# Altium schematic sheet [06] - RS232 INTERFACE.SchDoc("[06] - RS232 INTERFACE")
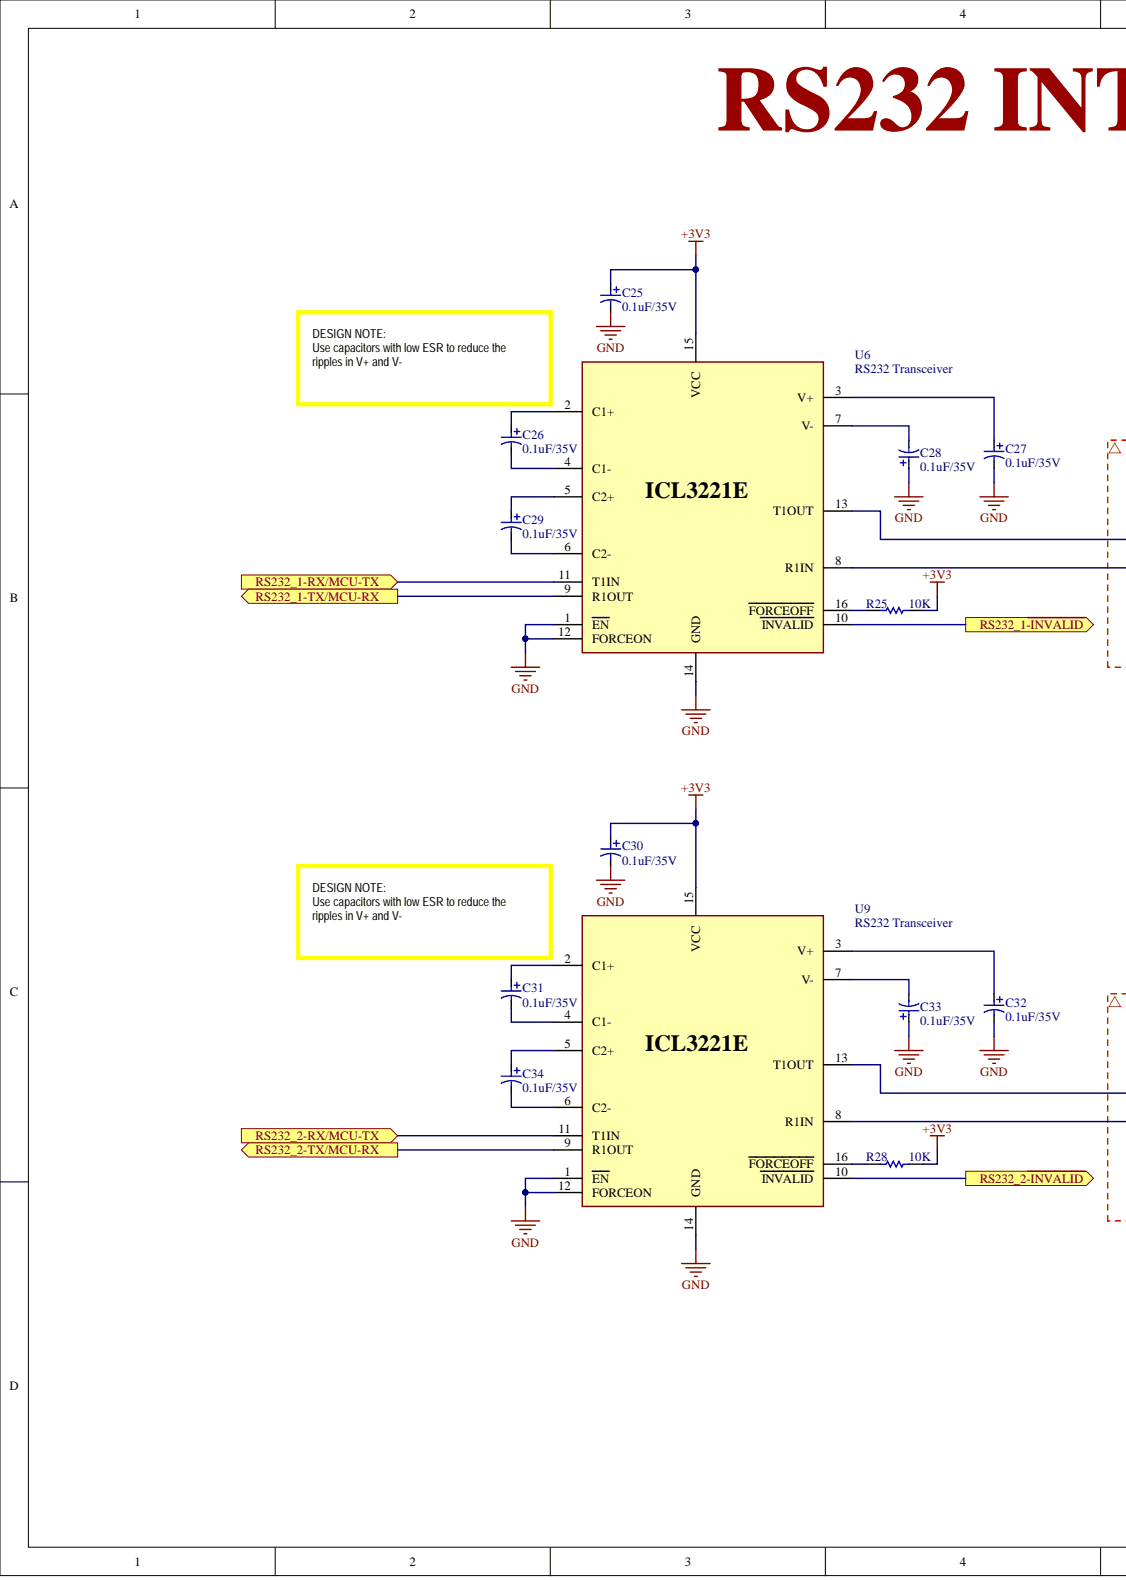
[diagram p0: [06] - RS232 INTERFACE.SchDoc, left]
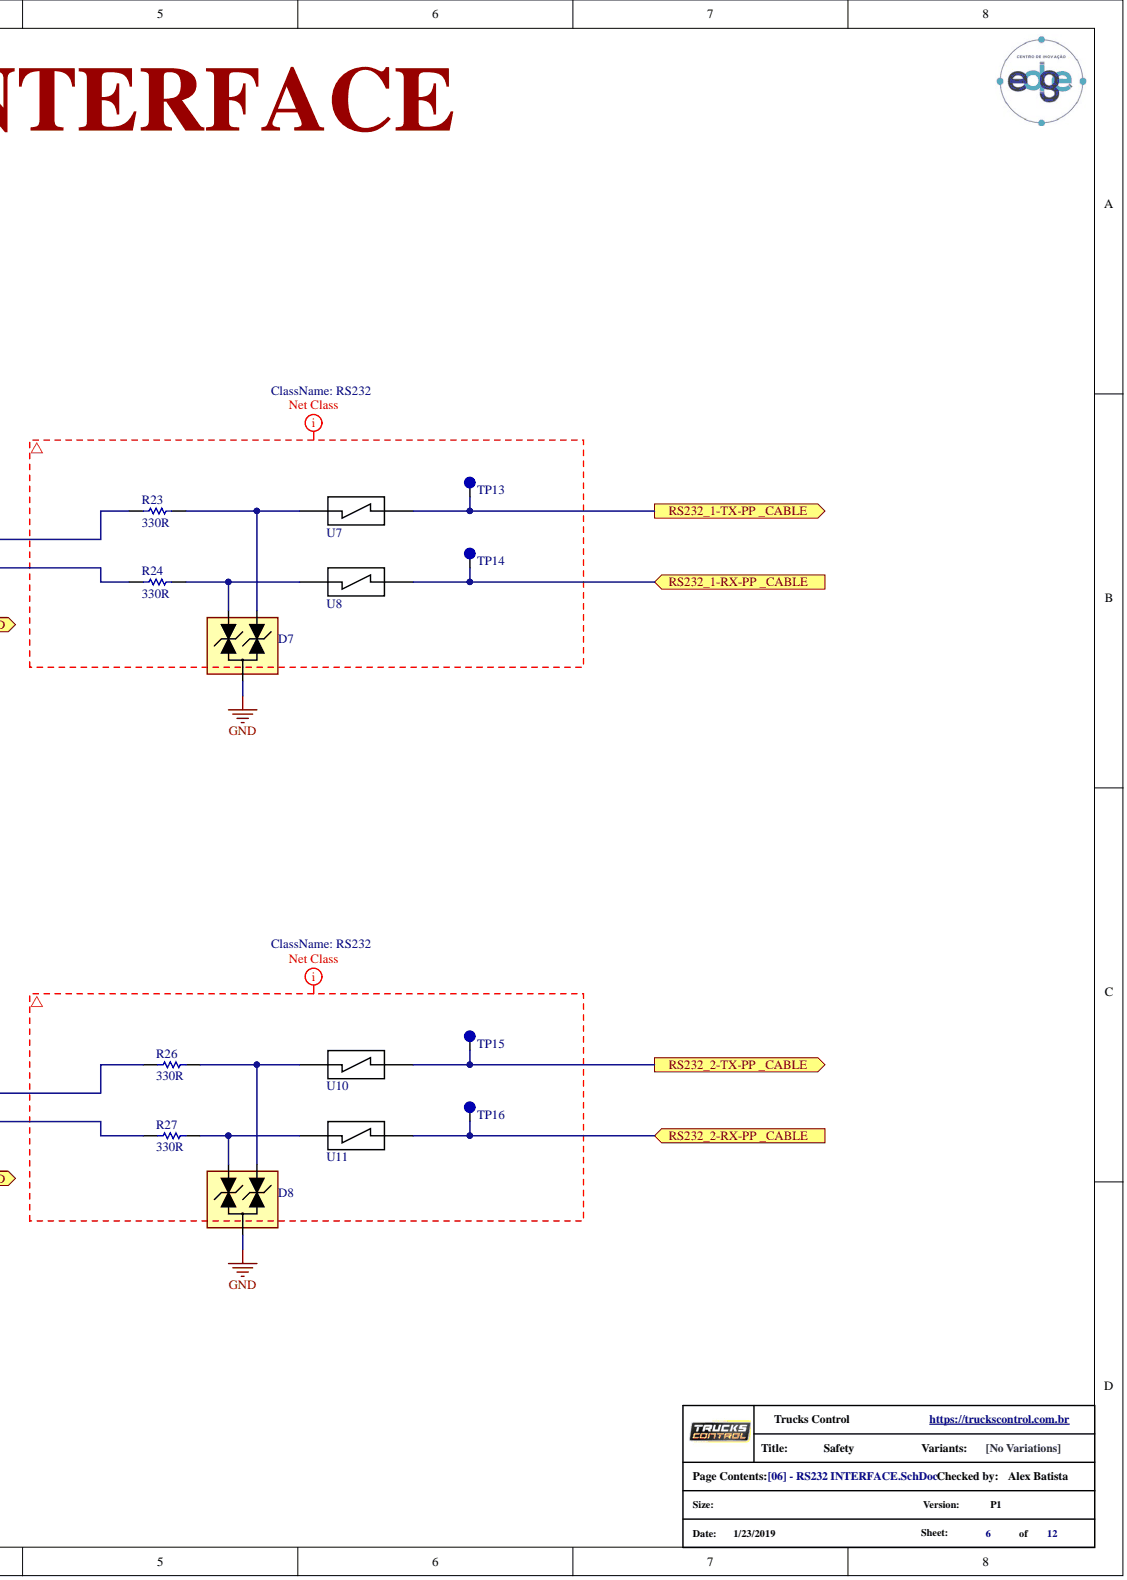
[diagram p1: [06] - RS232 INTERFACE.SchDoc, right]

## Parts
C25  0.1uF/35V  [Tantalum Capacitor Case A]
C26  0.1uF/35V  [Tantalum Capacitor Case A]
C27  0.1uF/35V  [Tantalum Capacitor Case A]
C28  0.1uF/35V  [Tantalum Capacitor Case A]
C29  0.1uF/35V  [Tantalum Capacitor Case A]
C30  0.1uF/35V  [Tantalum Capacitor Case A]
C31  0.1uF/35V  [Tantalum Capacitor Case A]
C32  0.1uF/35V  [Tantalum Capacitor Case A]
C33  0.1uF/35V  [Tantalum Capacitor Case A]
C34  0.1uF/35V  [Tantalum Capacitor Case A]
D7  TVS Diode  [SOT-23]  (value not drawn)
D8  TVS Diode  [SOT-23]  (value not drawn)
R23  330R  [R0402]
R24  330R  [R0402]
R25  10K  [R0402]
R26  330R  [R0402]
R27  330R  [R0402]
R28  10K  [R0402]
TP13  TestPoint  [Test Point]  (value not drawn)  (no BOM)
TP14  TestPoint  [Test Point]  (value not drawn)  (no BOM)
TP15  TestPoint  [Test Point]  (value not drawn)  (no BOM)
TP16  TestPoint  [Test Point]  (value not drawn)  (no BOM)
U6  RS232 Transceiver  [TSOP65P640X120-16N]
U7  TBU  [DFN-3]  (value not drawn)
U8  TBU  [DFN-3]  (value not drawn)
U9  RS232 Transceiver  [TSOP65P640X120-16N]
U10  TBU  [DFN-3]  (value not drawn)
U11  TBU  [DFN-3]  (value not drawn)

## Ports
RS232_1-RX-PP _CABLE, RS232_1-RX/MCU-TX, RS232_1-TX-PP _CABLE, RS232_1-TX/MCU-RX, RS232_1~{-INVALID}, RS232_2-RX-PP _CABLE, RS232_2-RX/MCU-TX, RS232_2-TX-PP _CABLE, RS232_2-TX/MCU-RX, RS232_2~{-INVALID}

## Nets
+3V3: C25.1 C30.1 R25.2 R28.2 U6.15(VCC) U9.15(VCC)
GND: C25.2 C27.2 C28.1 C30.2 C32.2 C33.1 D7.3 D8.3 U6.1(EN) U6.12(FORCEON) U6.14(GND) U9.1(EN) U9.12(FORCEON) U9.14(GND)
RS232_1~{-INVALID}: U6.10(INVALID) ; port RS232_1~{-INVALID}
RS232_1-RX-PP _CABLE: TP14.1 U8.3 ; port RS232_1-RX-PP _CABLE
RS232_1-RX/MCU-TX: U6.11(T1IN) ; port RS232_1-RX/MCU-TX
RS232_1-TX-PP _CABLE: TP13.1 U7.3 ; port RS232_1-TX-PP _CABLE
RS232_1-TX/MCU-RX: U6.9(R1OUT) ; port RS232_1-TX/MCU-RX
RS232_2~{-INVALID}: U9.10(INVALID) ; port RS232_2~{-INVALID}
RS232_2-RX-PP _CABLE: TP16.1 U11.3 ; port RS232_2-RX-PP _CABLE
RS232_2-RX/MCU-TX: U9.11(T1IN) ; port RS232_2-RX/MCU-TX
RS232_2-TX-PP _CABLE: TP15.1 U10.3 ; port RS232_2-TX-PP _CABLE
RS232_2-TX/MCU-RX: U9.9(R1OUT) ; port RS232_2-TX/MCU-RX
NetC26_1: C26.1 U6.2(C1+)
NetC26_2: C26.2 U6.4(C1-)
NetC27_1: C27.1 U6.3(V+)
NetC28_2: C28.2 U6.7(V-)
NetC29_1: C29.1 U6.5(C2+)
NetC29_2: C29.2 U6.6(C2-)
NetC31_1: C31.1 U9.2(C1+)
NetC31_2: C31.2 U9.4(C1-)
NetC32_1: C32.1 U9.3(V+)
NetC33_2: C33.2 U9.7(V-)
NetC34_1: C34.1 U9.5(C2+)
NetC34_2: C34.2 U9.6(C2-)
NetD7_1: D7.1 R24.2 U8.1
NetD7_2: D7.2 R23.2 U7.1
NetD8_1: D8.1 R27.2 U11.1
NetD8_2: D8.2 R26.2 U10.1
NetR23_1: R23.1 U6.13(T1OUT)
NetR24_1: R24.1 U6.8(R1IN)
NetR25_1: R25.1 U6.16(FORCEOFF)
NetR26_1: R26.1 U9.13(T1OUT)
NetR27_1: R27.1 U9.8(R1IN)
NetR28_1: R28.1 U9.16(FORCEOFF)
N00103:
N00104:

## Library data of the parts
C25-C34 = 293D104X0035A2TE3: CAP TANT 0.1UF 35V 20% 1206; Manufacturer: Vishay Sprague; Voltage Rating (DC): 300kV; Ripple Current: 60mA; Polarity: Polar; Leakage Current: 500nA; ESR (Equivalent Series Resistance): 20R; Dissipation Factor: 4%; Dielectric Material: Tantalum; Capacitance: 100nF; Width: 1.6mm; Weight: 0.000571oz; Voltage Rating: 35V; Tolerance: 20%; Termination: SMD/SMT; Supplier Part Number 1: 74-293D104X0035A2TE3; Supplier 1: Mouser; Stock 1: 1346; Radiation Hardening: No; Pricing 1: 1=0.18, 10=0.18, 50=0.18, 100=0.15, 1000=0.132, 10000=0.124 (USD); Packaging: Tape and Reel; Package Quantity: 2000; Mount: Surface Mount; Min Operating Temperature: -55°C; Max Operating Temperature: 125°C; Manufacturer Part Number: 293D104X0035A2TE3; Length: 3.2mm; Lead Free: Lead Free; Height - Seated (Max): 0.071inch; Height: 1.8mm; Features: General Purpose; Depth: 1.6mm; Component Kind: Standard; Case Code (Metric): 3216; Case Code (Imperial): 1206; Case/Package: 1206; Library Name: tc-pcb-safety_V1I1.SchLib
D7 D8 = CDSOT23-SM712: TVS DIODE 7VWM/12VWM SOT23; Manufacturer: Bourns; Working Voltage: 12V; Test Current: 1mA; Reverse Breakdown Voltage: 13.3V; Power Line Protection: No; Power Dissipation: 400W; Polarity: Bidirectional,Unidirectional; Peak Pulse Current: 17A; Number of Elements: 2; Max Surge Current: 30A; Max Reverse Leakage Current: 20uA; Max Power Dissipation: 600W; ESD Protection: Yes; Element Configuration: Dual; Direction: Bidirectional; Clamping Voltage: 26V; Capacitance: 75pF; Width: 0.055inch; Termination: SMD/SMT; Supplier Part Number 1: 652-CDSOT23-SM712; Supplier 1: Mouser; Stock 1: 8506; Reverse Standoff Voltage: 12V; REACH SVHC: No SVHC; Radiation Hardening: No; Pricing 1: 1=1.7, 10=1.37, 50=1.37, 100=1.05, 1000=0.731, 10000=0.63 (USD); Peak Pulse Power: 400W; Packaging: Tape and Reel; Package Quantity: 1; Number of Pins: 3; Number of Channels: 2; Number of Bidirectional Channels: 2; Mount: Surface Mount; Min Operating Temperature: -55°C; Min Breakdown Voltage: 13.3V; Max Operating Temperature: 150°C; Max Breakdown Voltage: 13.3V; Manufacturer Part Number: CDSOT23-SM712; Length: 3.04mm; Leakage Current: 20uA; Height: 1.11mm; Depth: 1.4mm; Contact Plating: Tin; Composition: Zener; Component Kind: Standard; Case/Package: SOT-23; Breakdown Voltage: 13.3V; Library Name: tc-pcb-safety_V1I1.SchLib
R23 R24 R26 R27 = RC0402JR-7D330RL: Resistor 330R 50V 0402 5%; Manufacturer: Yageo; Supplier Part Number 1: 603-RC0402JR-7D330RL; Supplier 1: Mouser; Stock 1: 179208; Pricing 1: 1=0.1, 10=0.01, 50=0.01, 100=0.004, 1000=0.003, 10000=0.002 (USD); Manufacturer Part Number: RC0402JR-7D330RL; ComponentLink1URL: http://datasheet.octopart.com/RC0402JR-7D330RL-Yageo-datasheet-73766026.pdf; ComponentLink1Description: http://datasheet.octopart.com/RC0402JR-7D330RL-Yageo-datasheet-73766026.pdf; Component Kind: Standard
  R27: Library Name: tc-pcb-tracker.SchLib
  R23 R24 R26: Library Name: tc-pcb-safety_V1I1.SchLib
R25 R28 = RC0402JR-7D10KL: 10K OHM 5% 1/16W 0402; Manufacturer: Yageo; Supplier Part Number 1: 603-RC0402JR-7D10KL; Supplier 1: Mouser; Stock 1: 178452; Pricing 1: 1=0.1, 10=0.01, 50=0.01, 100=0.004, 1000=0.003, 10000=0.002 (USD); Manufacturer Part Number: RC0402JR-7D10KL; Component Kind: Standard; Library Name: tc-pcb-safety_V1I1.SchLib
TP13-TP16 = Test_Point: Library Name: tc-pcb-safety_V1I1.SchLib
U6 U9 = ICL3221EIVZ-T: IC TXRX SGL RS232 3-5.5V 16TSSOP; Manufacturer: Intersil; Operating Supply Voltage: 5V; Number of Transmitters: 1; Nominal Supply Current: 1mA; Min Supply Voltage: 3V; Max Supply Voltage: 5.5V; Logic Function: Receiver,Transceiver; ESD Protection: Yes; Data Rate: 500Kbps; Supplier Part Number 1: 968-ICL3221EIVZ-T; Supplier 1: Mouser; Stock 1: 0; Packaging: Tape and Reel; Package Quantity: 1; Number of Transceivers: 1; Number of Receivers: 1; Number of Pins: 16; Number of Drivers: 1; Mount: Surface Mount; Min Operating Temperature: -40°C; Max Supply Current: 1mA; Max Operating Temperature: 85°C; Manufacturer Part Number: ICL3221EIVZ-T; Library Name: tc-pcb-tracker.SchLib; Lead Free: Lead Free; Contact Plating: Matte,Tin; Component Kind: Standard; Case/Package: TSSOP
U7 U8 U10 U11 = TBU-CA025-050-WH: SURGE SUPP TBU 50MA 250VIMP SMD; Manufacturer: Bourns; Working Voltage: 100V; Voltage Rating (DC): 250V; Reverse Standoff Voltage: 20V; Response Time: 1us; Peak Pulse Current: 100mA; Number of Circuits: 2; ESD Protection: Yes; Element Configuration: Single; Direction: Bidirectional; Width: 0.157inch; Weight: 0.076oz; Voltage Rating: 250V; Termination: Solder; Supplier Part Number 1: 652-TBU-CA025-050-WH; Supplier 1: Mouser; Stock 1: 23479; REACH SVHC: No SVHC; Pricing 1: 1=1.84, 10=1.49, 50=1.49, 100=1.19, 1000=0.863, 10000=0.77 (USD); Packaging: Tape and Reel; Package Quantity: 3000; Number of Pins: 12; Mount: Surface Mount; Min Operating Temperature: -40°C; Max Operating Temperature: 125°C; Manufacturer Part Number: TBU-CA025-050-WH; Length: 0.255inch; Height: 0.033inch; Current Rating: 50mA; Component Kind: Standard; Clamping Voltage: 250V; Case/Package: DFN; Library Name: tc-pcb-safety_V1I1.SchLib
